# Altium schematic sheet turrets.SchDoc
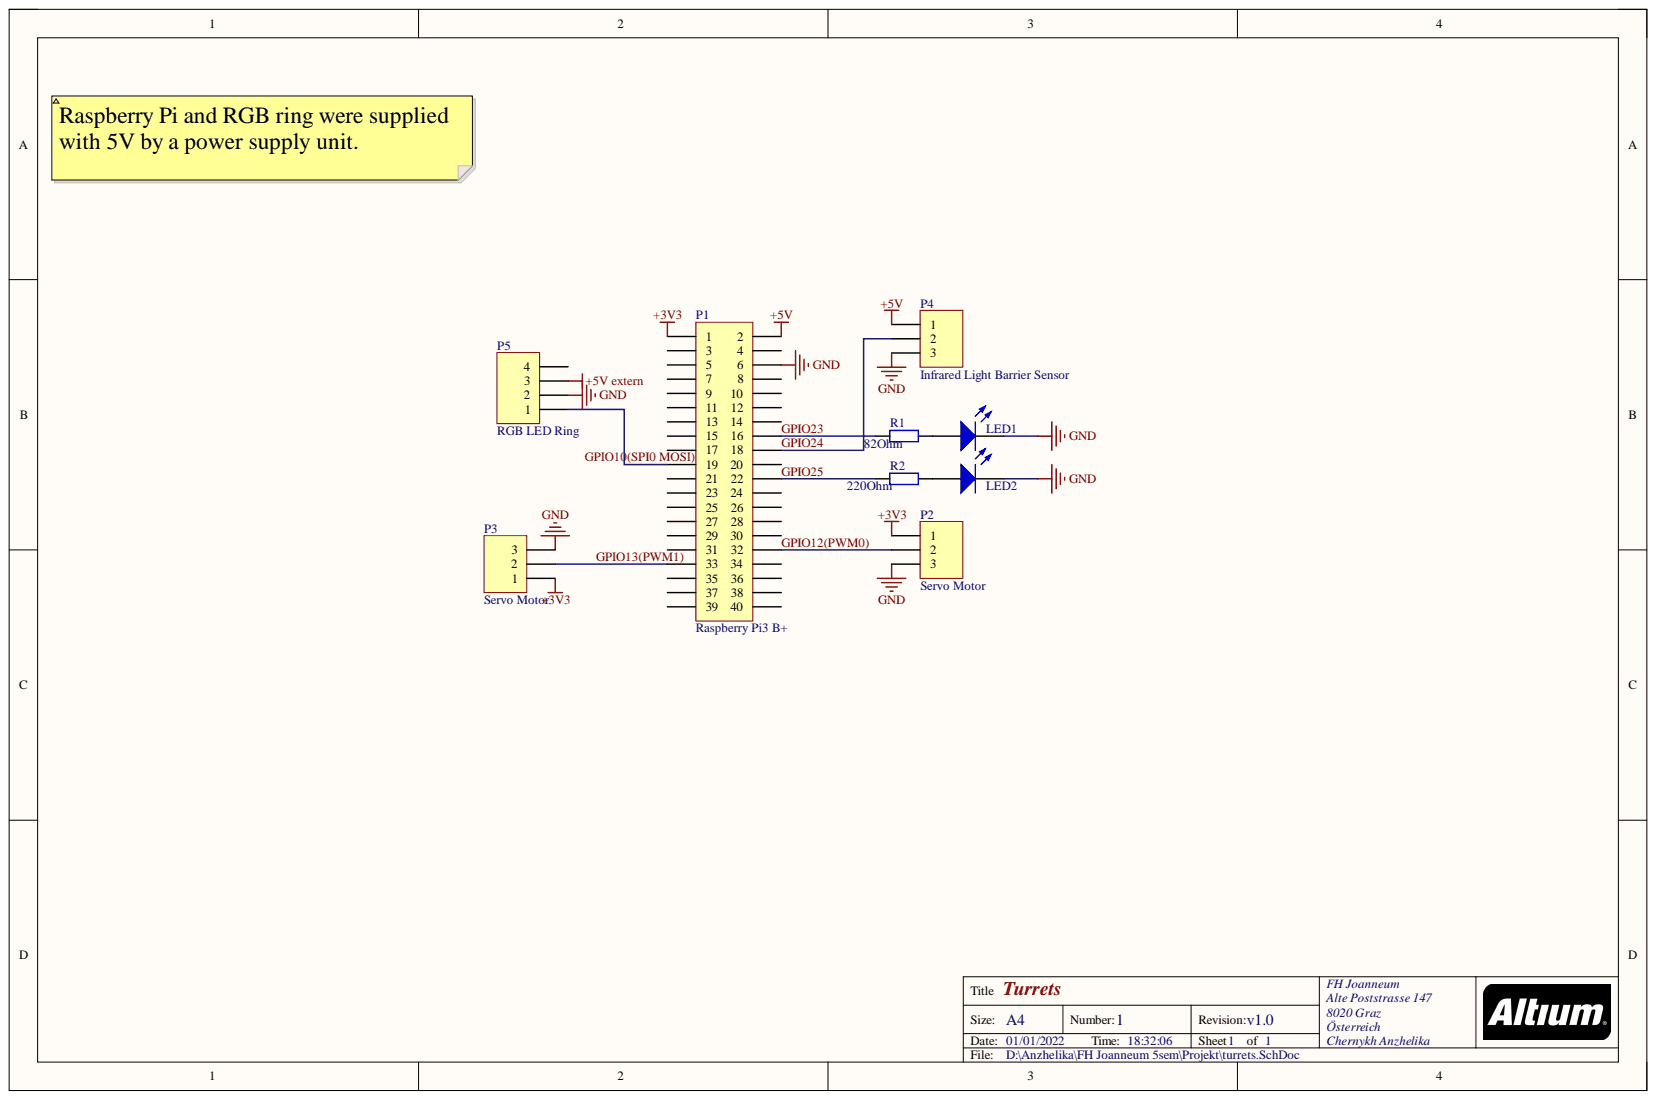
[diagram p0: turrets.SchDoc]

## Parts
P1  Raspberry Pi3 B+  [HDR2X20H]
P2  Servo Motor  [HDR1X3H]
P3  Servo Motor  [HDR1X3H]
P4  Infrared Light Barrier Sensor  [HDR1X3H]
P5  RGB LED Ring  [HDR1X4H]
R1  value 82Ohm  [AXIAL-0.4]
R2  value 220Ohm  [AXIAL-0.4]
  LED2  [LED-0]
  LED1  [LED-0]

## Nets
+3V3: P1.1 P2.1 P3.1
+5V: P1.2 P4.1
+5V extern: P5.3
GND: P1.6 P2.3 P3.3 P4.3 P5.2 .2
Net_1: R1.1 R2.2 .1
GPIO12(PWM0): P1.32 P2.2
GPIO13(PWM1): P1.33 P3.2
GPIO23: P1.16 R1.2
GPIO24: P1.18 P4.2
GPIO25: P1.22 R2.1
NetP1_19: P1.19 P5.1
GPIO10(SPI0 MOSI):

Not connected: P1 3 4 5 7 8 9 10 11 12 13 14 15 17 20 21 23 24 25 26 27 28 29 30 31 34 35 36 37 38 39 40; P5 4

## Library data of the parts
P1 = Header 20X2H: Header, 20-Pin, Dual row, Right Angle; Component Kind: Standard; LatestRevisionDate: 17-Jul-2002; LatestRevisionNote: Re-released for DXP Platform.; Library Name: Miscellaneous Connectors.IntLib; Publisher: Altium Limited
P2-P4 = Header 3H: Header, 3-Pin, Right Angle; Component Kind: Standard; LatestRevisionDate: 17-Jul-2002; LatestRevisionNote: Re-released for DXP Platform.; Library Name: Miscellaneous Connectors.IntLib; Publisher: Altium Limited
P5 = Header 4H: Header, 4-Pin, Right Angle; Component Kind: Standard; LatestRevisionDate: 17-Jul-2002; LatestRevisionNote: Re-released for DXP Platform.; Library Name: Miscellaneous Connectors.IntLib; Publisher: Altium Limited
R1 R2 = Res2: Resistor; Component Kind: Standard; LatestRevisionDate: 17-Jul-2002; LatestRevisionNote: Re-released for DXP Platform.; Library Name: Miscellaneous Devices.IntLib; PackageDescription: Axial Device, Thru-Hole; 2 Leads; 0.4 in Pin Spacing; PackageReference: AXIAL-0.4; Published: 8-Jun-2000; Simulation: RESISTOR; Publisher: Altium Limited
  = LED0: Typical INFRARED GaAs LED; Component Kind: Standard; LatestRevisionDate: 08-Jul-2005; LatestRevisionNote: Mech Layer 1 removed.; Library Name: Miscellaneous Devices.IntLib; PackageDescription: LED; 2 Leads; PackageReference: LED-0; Published: 8-Jun-2000; Simulation: LED0; Publisher: Altium Limited
